# Altium schematic sheet MCU.SchDoc(MCU)
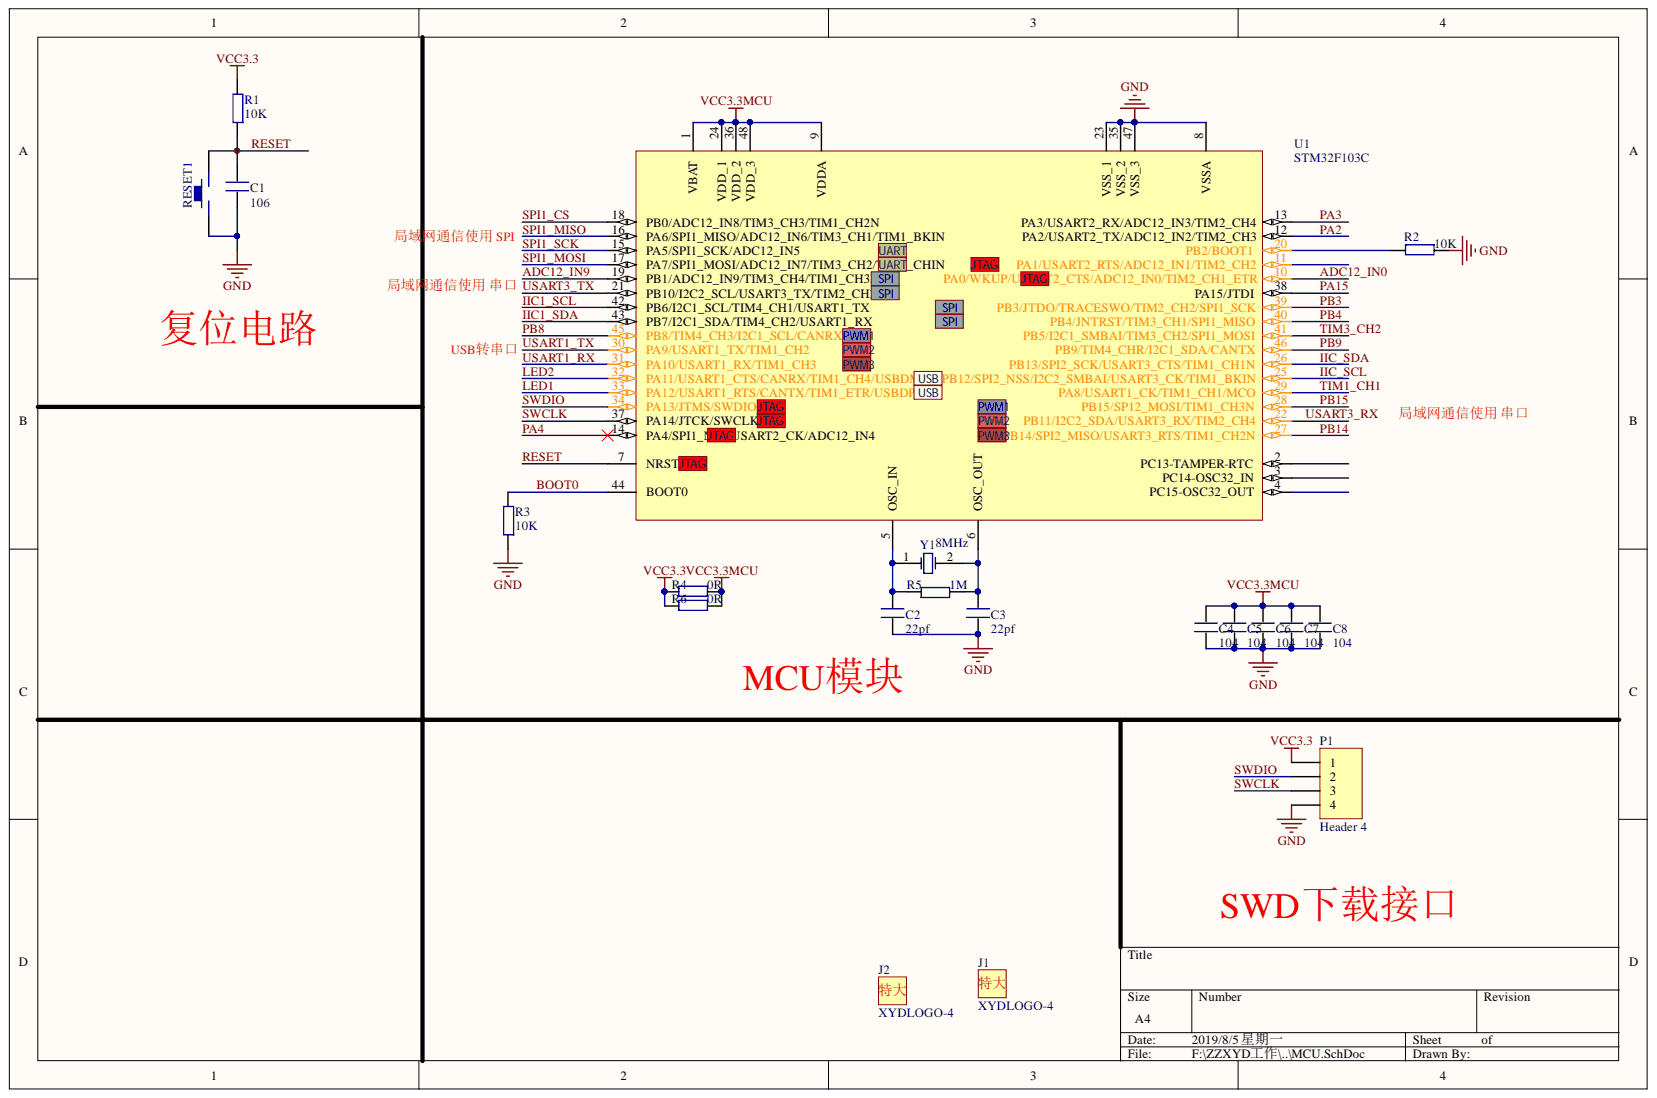
[diagram p0: MCU.SchDoc]

## Parts
C1  value 106  [0805C]  (hidden comment: CAP)
C2  value 22pf  [0805C]  (hidden comment: CAP)
C3  value 22pf  [0805C]  (hidden comment: CAP)
C4  value 104  [0805C]  (hidden comment: CAP)
C5  value 104  [0805C]  (hidden comment: CAP)
C6  value 104  [0805C]  (hidden comment: CAP)
C7  value 104  [0805C]  (hidden comment: CAP)
C8  value 104  [0805C]  (hidden comment: CAP)
J1  XYDLOGO-4  [XYDLOGO_4]
J2  XYDLOGO-4  [XYDLOGO_4]
P1  Header 4  [HDR1X4]
R1  RES  value 10K  [0805R]
R2  value 10K  [0805R]  (hidden comment: RES)
R3  RES  value 10K  [0805R]
R4  value 0R  [0805R]  (hidden comment: RES)
R5  value 1M  [0805R]  (hidden comment: RES)
R6  value 0R  [0805R]  (hidden comment: RES)
RESET1  KEY_M  [KEY]  (value not drawn)
U1  STM32F103C  [LQFP48]
Y1  8MHz  [XTAL_US]

## Nets
GND: C1.2 C2.2 C3.2 C4.1 C5.2 C6.2 C7.2 C8.2 P1.4 R2.1 R3.1 RESET1.1 U1.8(VSSA) U1.23(VSS_1) U1.35(VSS_2) U1.47(VSS_3)
VCC3.3: P1.1 R1.2 R4.2 R6.2
VCC3.3MCU: C4.2 C5.1 C6.1 C7.1 C8.1 R4.1 R6.1 U1.1(VBAT) U1.9(VDDA) U1.24(VDD_1) U1.36(VDD_2) U1.48(VDD_3)
ADC12_IN0: U1.10(PA0/WKUP/USART2_CTS/ADC12_IN0/TIM2_CH1_ETR)
ADC12_IN9: U1.19(PB1/ADC12_IN9/TIM3_CH4/TIM1_CH3N_SPI)
BOOT0: R3.2 U1.44(BOOT0)
IIC1_SCL: U1.42(PB6/I2C1_SCL/TIM4_CH1/USART1_TX)
IIC1_SDA: U1.43(PB7/I2C1_SDA/TIM4_CH2/USART1_RX)
IIC_SCL: U1.25
IIC_SDA: U1.26(PB13/SPI2_SCK/USART3_CTS/TIM1_CH1N)
LED1: U1.33(PA12/USART1_RTS/CANTX/TIM1_ETR/USBDP_USB)
LED2: U1.32(PA11/USART1_CTS/CANRX/TIM1_CH4/USBDM)
PA2: U1.12(PA2/USART2_TX/ADC12_IN2/TIM2_CH3)
PA3: U1.13(PA3/USART2_RX/ADC12_IN3/TIM2_CH4)
PA4: U1.14(PA4/SPI1_NSS/USART2_CK/ADC12_IN4)
PA15: U1.38(PA15/JTDI)
PB3: U1.39(PB3/JTDO/TRACESWO/TIM2_CH2/SPI1_SCK)
PB4: U1.40(PB4/JNTRST/TIM3_CH1/SPI1_MISO)
PB8: U1.45(PB8/TIM4_CH3/I2C1_SCL/CANRX_PWM1)
PB9: U1.46(PB9/TIM4_CHR/I2C1_SDA/CANTX)
PB14: U1.27(PB14/SPI2_MISO/USART3_RTS/TIM1_CH2N)
PB15: U1.28(PB15/SP12_MOSI/TIM1_CH3N)
RESET: C1.1 R1.1 RESET1.2 U1.7(NRST_JTAG)
SPI1_CS: U1.18(PB0/ADC12_IN8/TIM3_CH3/TIM1_CH2N)
SPI1_MISO: U1.16(PA6/SPI1_MISO/ADC12_IN6/TIM3_CH1/TIM1_BKIN)
SPI1_MOSI: U1.17(PA7/SPI1_MOSI/ADC12_IN7/TIM3_CH2/TIM1_CHIN)
SPI1_SCK: U1.15(PA5/SPI1_SCK/ADC12_IN5)
SWCLK: P1.3 U1.37(PA14/JTCK/SWCLK)
SWDIO: P1.2 U1.34(PA13/JTMS/SWDIO_JTAG)
TIM1_CH1: U1.29(PA8/USART1_CK/TIM1_CH1/MCO)
TIM3_CH2: U1.41(PB5/I2C1_SMBAI/TIM3_CH2/SPI1_MOSI)
USART1_RX: U1.31(PA10/USART1_RX/TIM1_CH3)
USART1_TX: U1.30(PA9/USART1_TX/TIM1_CH2)
USART3_RX: U1.22(PB11/I2C2_SDA/USART3_RX/TIM2_CH4)
USART3_TX: U1.21(PB10/I2C2_SCL/USART3_TX/TIM2_CH3_SPI)
NetC2_1: C2.1 R5.1 U1.5(OSC_IN) Y1.1
NetC3_1: C3.1 R5.2 U1.6(OSC_OUT) Y1.2
NetR2_2: R2.2 U1.20(PB2/BOOT1)

Not connected: U1 2(PC13-TAMPER-RTC) 3(PC14-OSC32_IN) 4(PC15-OSC32_OUT) 11(PA1/USART2_RTS/ADC12_IN1/TIM2_CH2)

## Library data of the parts
C1-C8 = C: 瓷片电容; Library Name: WarShip V3 STM32103封装库.IntLib; Component Kind: Standard
P1 = Header 4: Header, 4-Pin; Signal Integrity: Connector; Library Name: Miscellaneous Connectors.IntLib; LatestRevisionNote: Re-released for DXP Platform.; LatestRevisionDate: 17-Jul-2002; Component Kind: Standard; Publisher: Altium Limited
R1-R6 = R: 电阻; Library Name: WarShip V3 STM32103封装库.IntLib; Component Kind: Standard
U1 = STM32F103C(48): Component Kind: Standard; Library Name: PID.SchLib
Y1 = XTAL: Crystal Oscillator; Simulation: XTAL; Published: 8-Jun-2000; Library Name: WarShip V3 STM32103封装库.IntLib; LatestRevisionNote: Re-released for DXP Platform.; LatestRevisionDate: 17-Jul-2002; Component Kind: Standard; Publisher: Altium Limited
J1 J2 = XYDLOGO-4: Component Kind: Standard; Library Name: 常用器件库.IntLib
RESET1 = KEY_M: Library Name: WarShip V3 STM32103封装库.IntLib; Component Kind: Standard
